# Altium schematic sheet iMX6SL_SoC.SchDoc
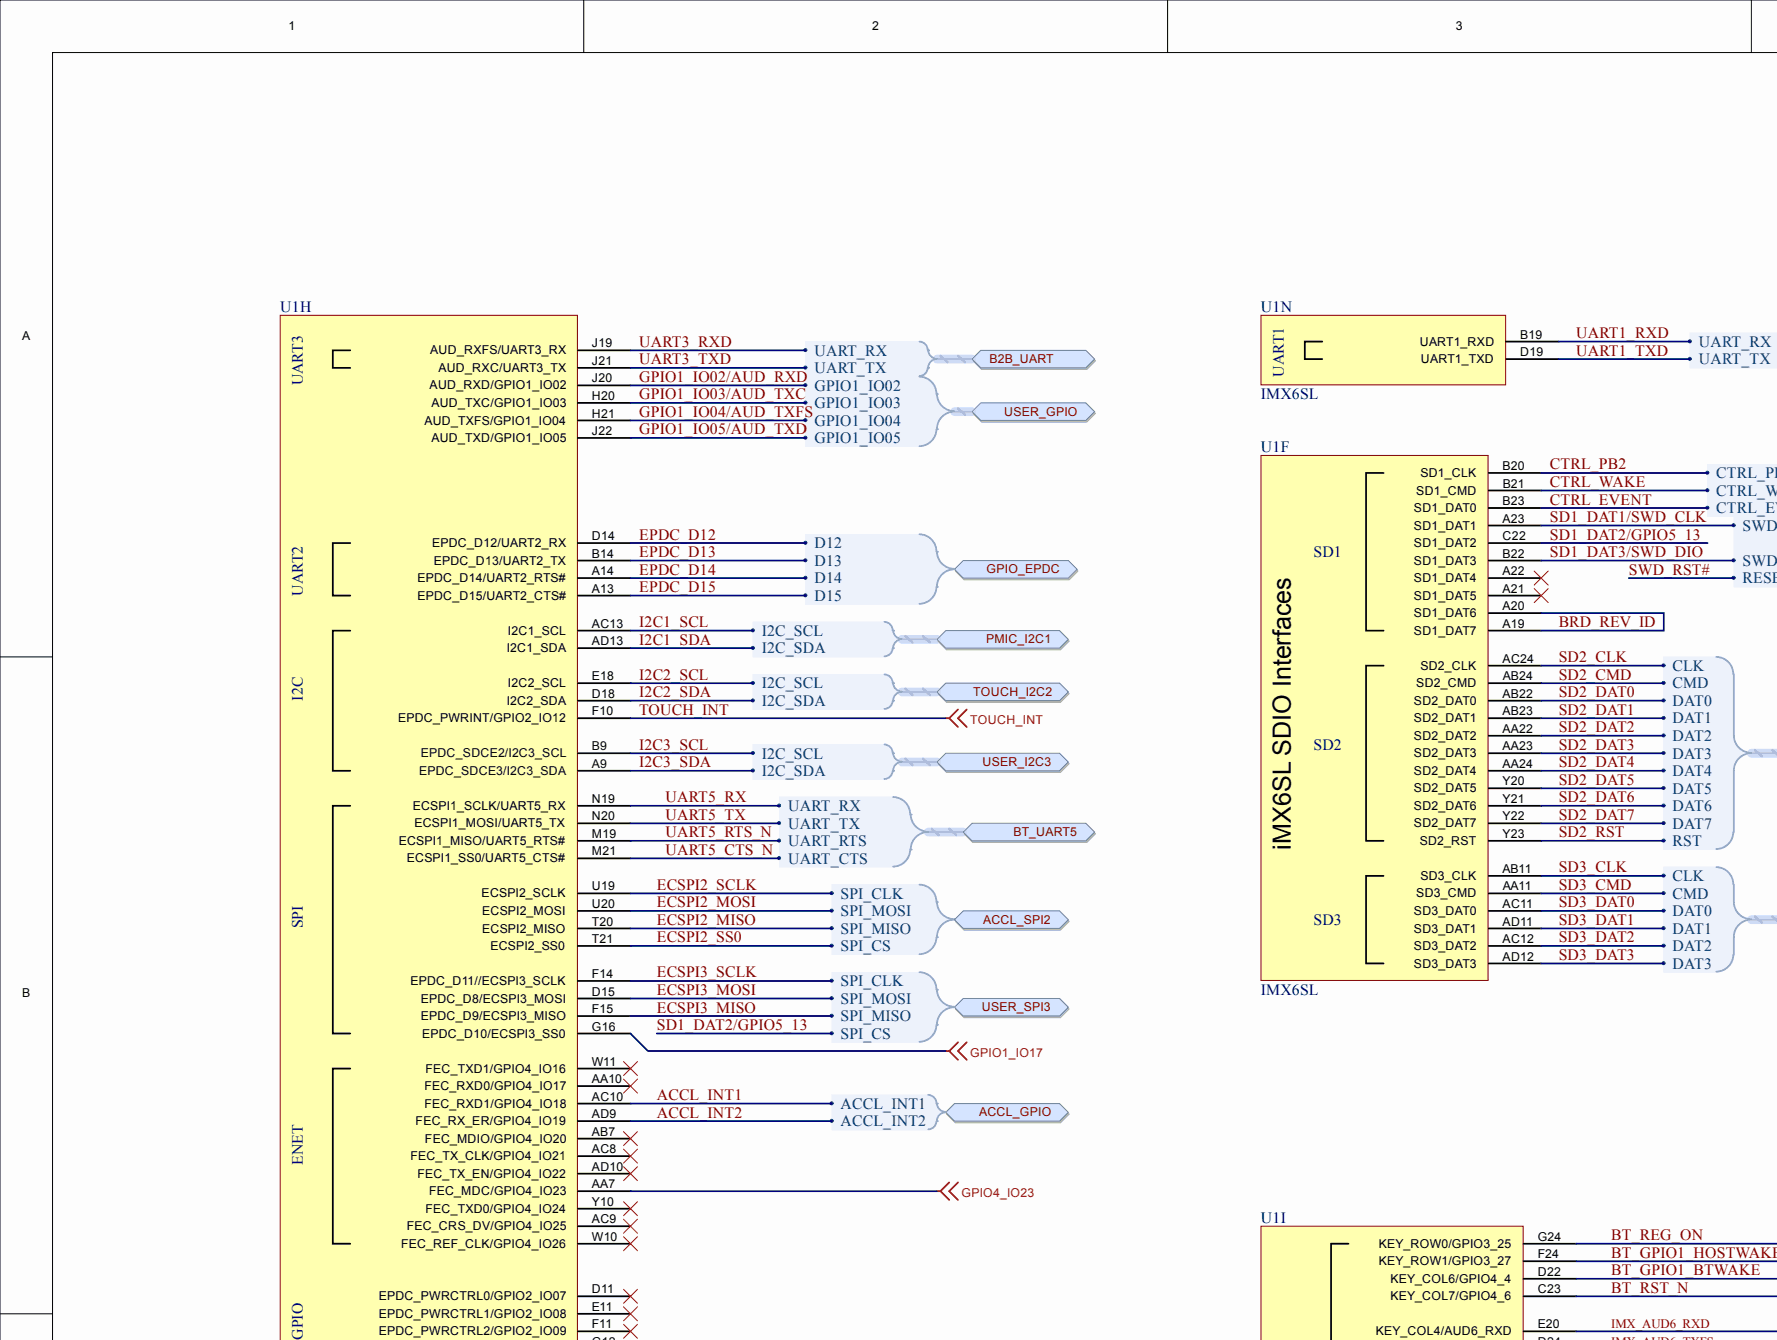
[diagram p0: iMX6SL_SoC.SchDoc, top left]
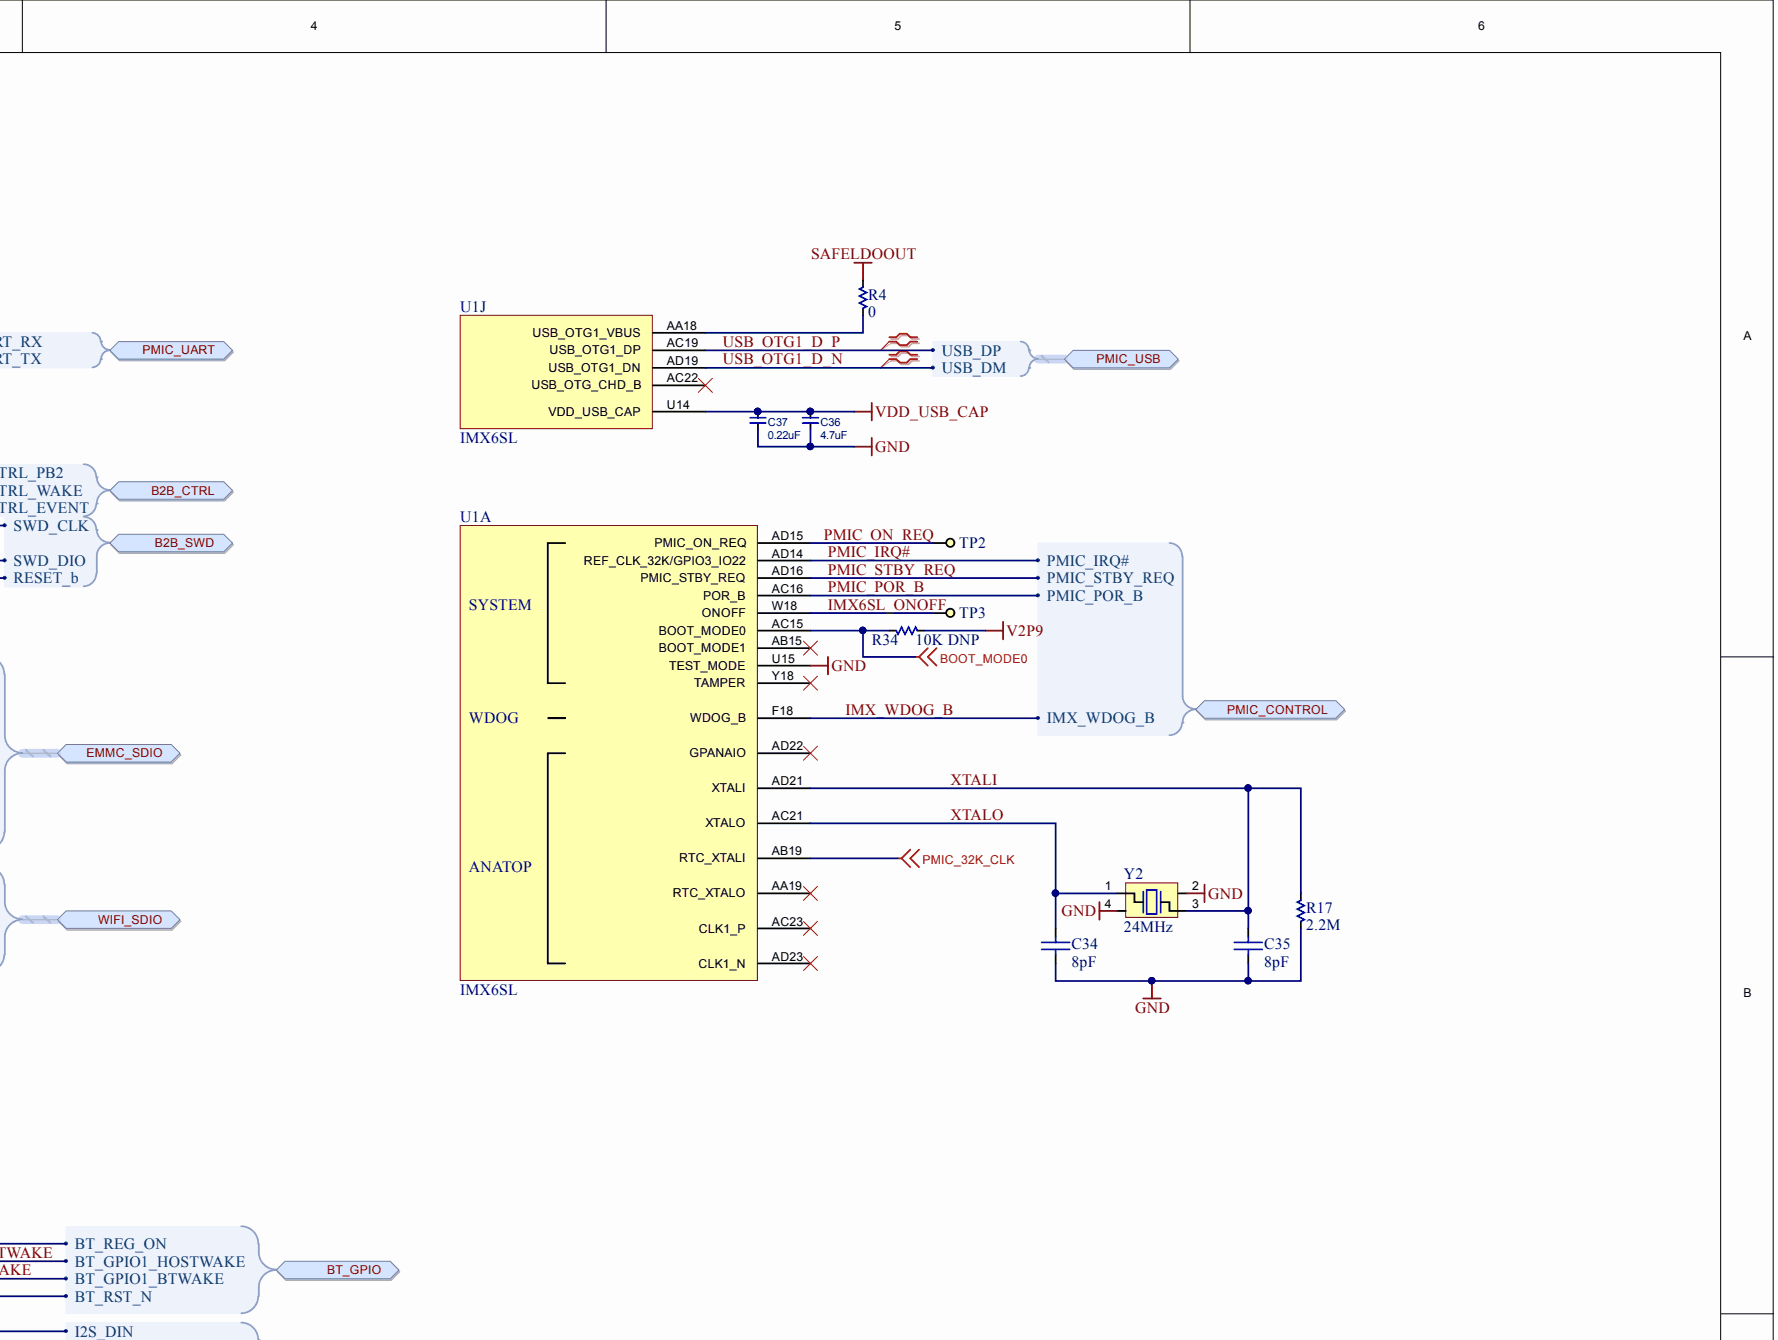
[diagram p1: iMX6SL_SoC.SchDoc, top right]
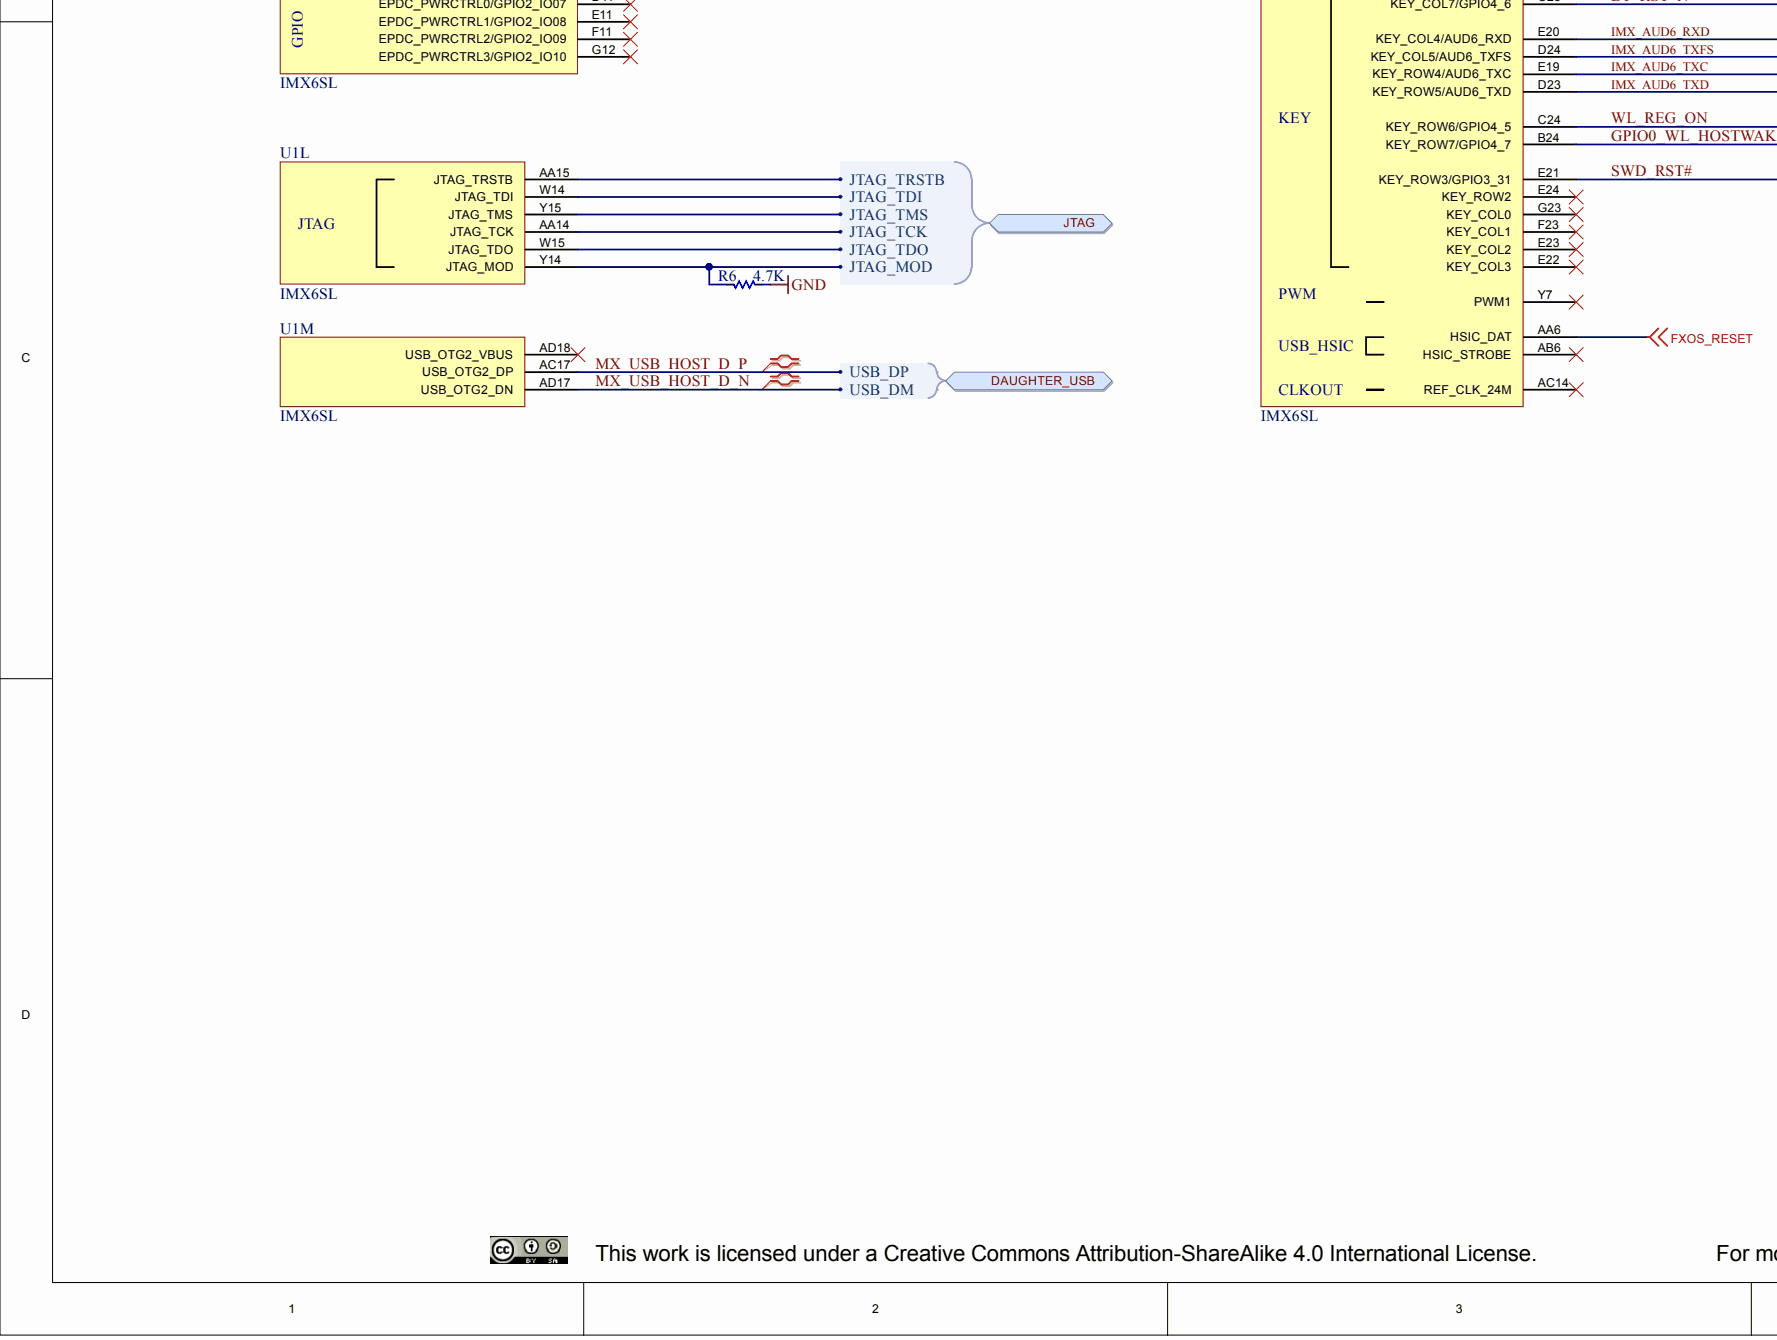
[diagram p2: iMX6SL_SoC.SchDoc, bottom left]
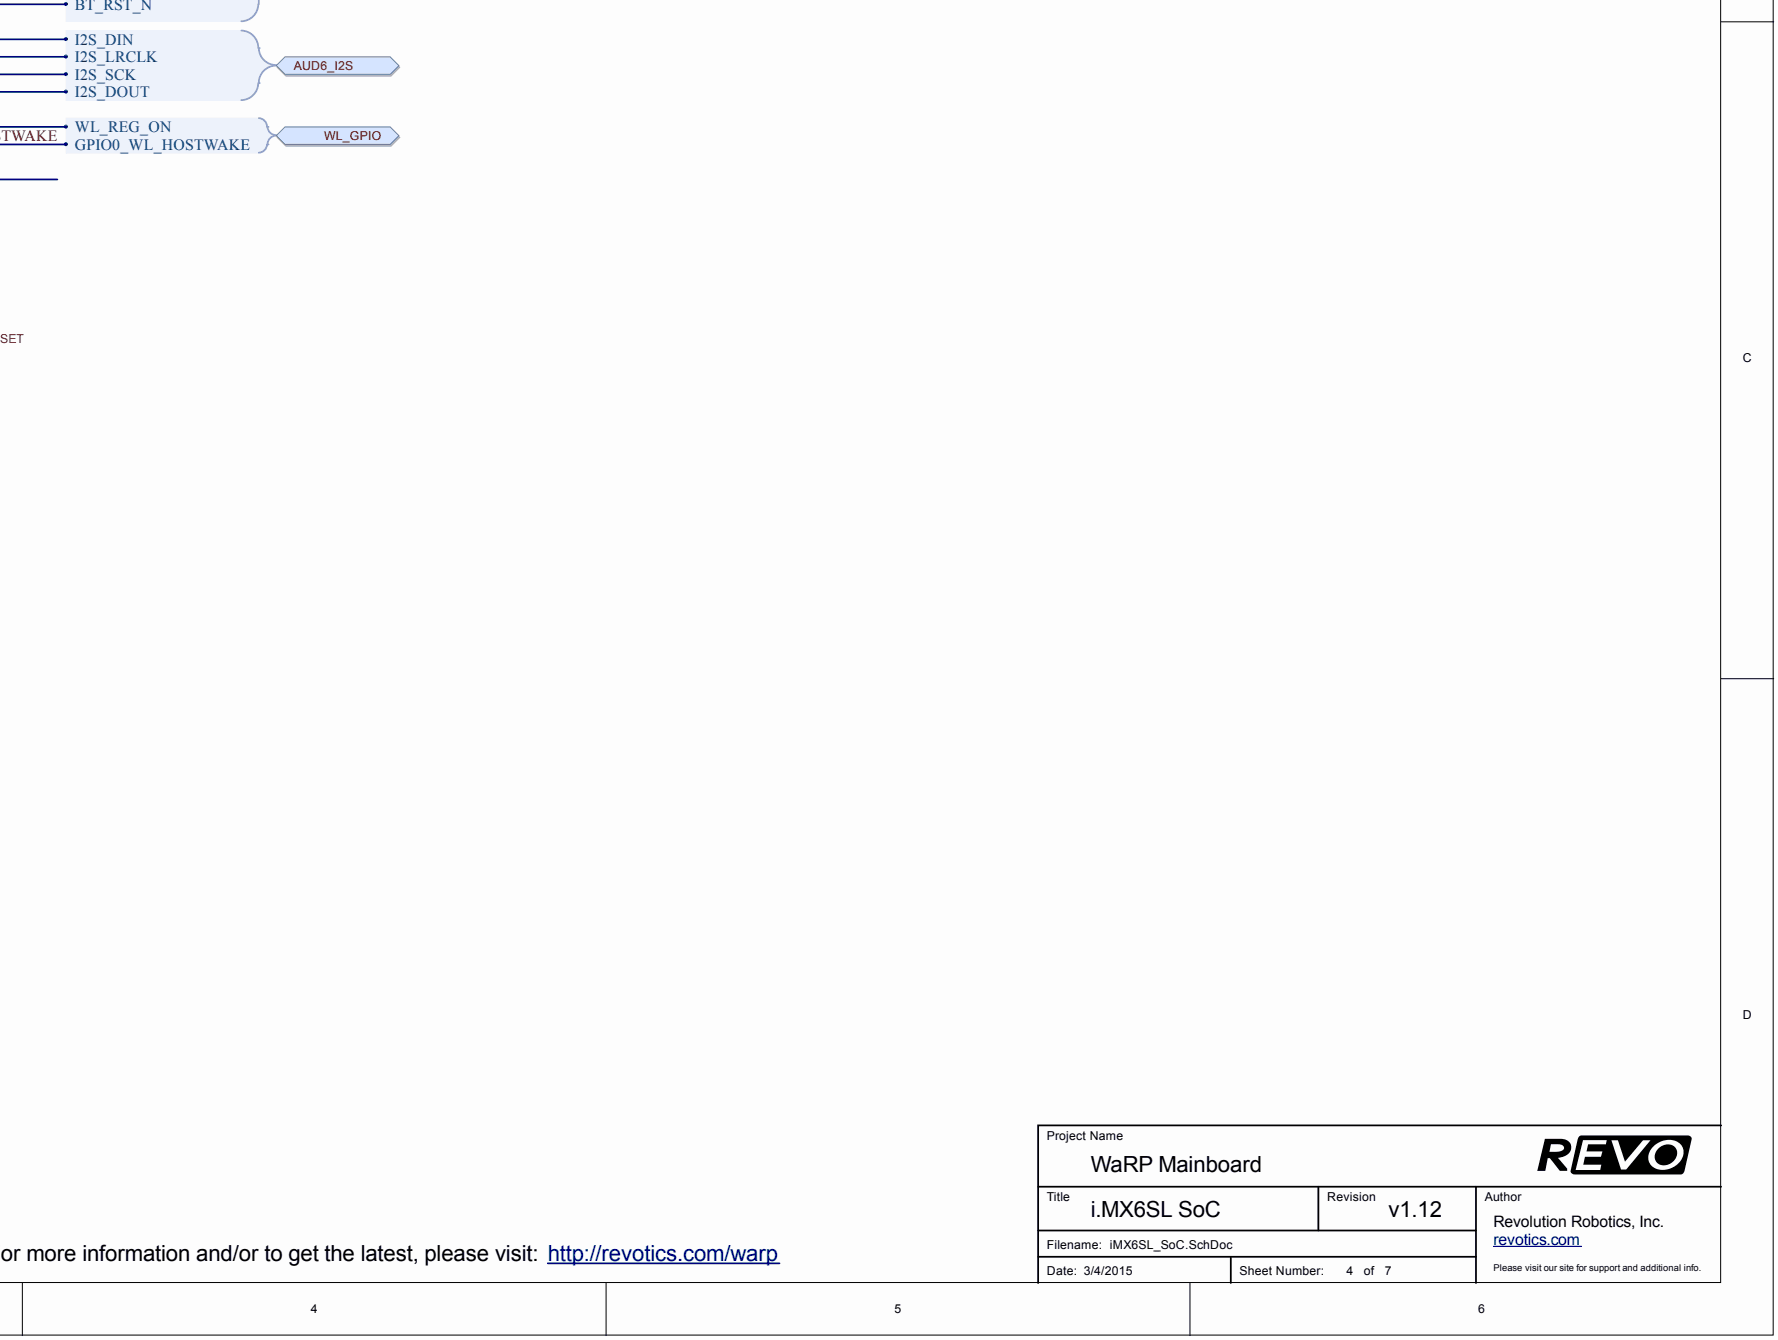
[diagram p3: iMX6SL_SoC.SchDoc, bottom right]

## Parts
C34  value 8pF  [0201 (0603) Cap High Density]  (hidden comment: Capacitor)
C35  value 8pF  [0201 (0603) Cap High Density]  (hidden comment: Capacitor)
C36  value 4.7uF  [0402 (1005) Cap High Density]  (hidden comment: CapacitorSM)
C37  value 0.22uF  [0201 (0603) Cap High Density]  (hidden comment: CapacitorSM)
R4  value 0  [0201 (0603) Res High Density]  (hidden comment: Resistor)
R6  value 4.7K  [0201 (0603) Res High Density]  (hidden comment: Resistor)
R17  Resistor  [0201 (0603) Res High Density]  (value not drawn)
R34  value 10K DNP  [0201 (0603) Res High Density]  (hidden comment: Resistor)
TP2  TP  [8mil]  (no BOM)
TP3  TP  [8mil]  (no BOM)
U1  IMX6SL  [BGA432C50P24X24_1300X1300X110]  (parts A,F,H,I,J,L,M,N)
Y2  value 24MHz  [TXC 1.6mm x 1.2mm]  (hidden comment: XTAL 4Pad SMD)

## Ports
ACCL_GPIO, ACCL_GPIO.ACCL_INT1, ACCL_GPIO.ACCL_INT2, ACCL_SPI2, ACCL_SPI2.SPI_CLK, ACCL_SPI2.SPI_CS, ACCL_SPI2.SPI_MISO, ACCL_SPI2.SPI_MOSI, AUD6_I2S, AUD6_I2S.I2S_DIN, AUD6_I2S.I2S_DOUT, AUD6_I2S.I2S_LRCLK, AUD6_I2S.I2S_SCK, B2B_CTRL, B2B_CTRL.CTRL_EVENT, B2B_CTRL.CTRL_PB2, B2B_CTRL.CTRL_WAKE, B2B_SWD, B2B_SWD.RESET_B, B2B_SWD.SWD_CLK, B2B_SWD.SWD_DIO, B2B_UART, B2B_UART.UART_RX, B2B_UART.UART_TX, BT_GPIO, BT_GPIO.BT_GPIO1_BTWAKE, BT_GPIO.BT_GPIO1_HOSTWAKE, BT_GPIO.BT_REG_ON, BT_GPIO.BT_RST_N, BT_UART5, BT_UART5.UART_CTS, BT_UART5.UART_RTS, BT_UART5.UART_RX, BT_UART5.UART_TX, DAUGHTER_USB, DAUGHTER_USB.USB_DM, DAUGHTER_USB.USB_DP, EMMC_SDIO, EMMC_SDIO.CLK, EMMC_SDIO.CMD, EMMC_SDIO.DAT0, EMMC_SDIO.DAT1, EMMC_SDIO.DAT2, EMMC_SDIO.DAT3, EMMC_SDIO.DAT4, EMMC_SDIO.DAT5, EMMC_SDIO.DAT6, EMMC_SDIO.DAT7, EMMC_SDIO.RST, GPIO_EPDC, GPIO_EPDC.D12, GPIO_EPDC.D13, GPIO_EPDC.D14, GPIO_EPDC.D15, JTAG, JTAG.JTAG_MOD, JTAG.JTAG_TCK, JTAG.JTAG_TDI, JTAG.JTAG_TDO, JTAG.JTAG_TMS, JTAG.JTAG_TRSTB, PMIC_CONTROL, PMIC_CONTROL.IMX_WDOG_B, PMIC_CONTROL.PMIC_IRQ#, PMIC_CONTROL.PMIC_POR_B, PMIC_CONTROL.PMIC_STBY_REQ, PMIC_I2C1, PMIC_I2C1.I2C_SCL, PMIC_I2C1.I2C_SDA, PMIC_UART, PMIC_UART.UART_RX, PMIC_UART.UART_TX, PMIC_USB, PMIC_USB.USB_DM, PMIC_USB.USB_DP, TOUCH_I2C2, TOUCH_I2C2.I2C_SCL, TOUCH_I2C2.I2C_SDA, USER_GPIO, USER_GPIO.GPIO1_IO02, USER_GPIO.GPIO1_IO03, USER_GPIO.GPIO1_IO04, USER_GPIO.GPIO1_IO05, USER_I2C3, USER_I2C3.I2C_SCL, USER_I2C3.I2C_SDA, USER_SPI3, USER_SPI3.SPI_CLK, USER_SPI3.SPI_CS, USER_SPI3.SPI_MISO, USER_SPI3.SPI_MOSI, WIFI_SDIO, WIFI_SDIO.CLK, WIFI_SDIO.CMD, WIFI_SDIO.DAT0, WIFI_SDIO.DAT1, WIFI_SDIO.DAT2, WIFI_SDIO.DAT3, WL_GPIO, WL_GPIO.GPIO0_WL_HOSTWAKE, WL_GPIO.WL_REG_ON

## Nets
BOOT_MODE0: R34.2 U1.AC15
FXOS_RESET: U1.AA6
GND: C34.2 C35.2 C36.2 C37.2 R6.1 R17.2 U1.U15 Y2.2 Y2.4
GPIO1_IO17: U1.G16
GPIO4_IO23: U1.AA7
PMIC_32K_CLK: U1.AB19
SAFELDOOUT: R4.1
V2P9: R34.1
VDD_USB_CAP: C36.1 C37.1 U1.U14
ACCL_INT1: U1.AC10 ; port ACCL_GPIO
ACCL_INT2: U1.AD9 ; port ACCL_GPIO
BRD_REV1.11: U1.A19 U1.A20
BT_GPIO1_BTWAKE: U1.D22 ; port BT_GPIO
BT_GPIO1_HOSTWAKE: U1.F24 ; port BT_GPIO
BT_REG_ON: U1.G24 ; port BT_GPIO
BT_RST_N: U1.C23 ; port BT_GPIO
CTRL_EVENT: U1.B23 ; port B2B_CTRL
CTRL_PB2: U1.B20 ; port B2B_CTRL
CTRL_WAKE: U1.B21 ; port B2B_CTRL
ECSPI2_MISO: U1.T20 ; port ACCL_SPI2
ECSPI2_MOSI: U1.U20 ; port ACCL_SPI2
ECSPI2_SCLK: U1.U19 ; port ACCL_SPI2
ECSPI2_SS0: U1.T21 ; port ACCL_SPI2
ECSPI3_MISO: U1.F15 ; port USER_SPI3
ECSPI3_MOSI: U1.D15 ; port USER_SPI3
ECSPI3_SCLK: U1.F14 ; port USER_SPI3
EPDC_D12: U1.D14 ; port GPIO_EPDC
EPDC_D13: U1.B14 ; port GPIO_EPDC
EPDC_D14: U1.A14 ; port GPIO_EPDC
EPDC_D15: U1.A13 ; port GPIO_EPDC
GPIO0_WL_HOSTWAKE: U1.B24 ; port WL_GPIO
GPIO1_IO02/AUD_RXD: U1.J20 ; port USER_GPIO
GPIO1_IO03/AUD_TXC: U1.H20 ; port USER_GPIO
GPIO1_IO04/AUD_TXFS: U1.H21 ; port USER_GPIO
GPIO1_IO05/AUD_TXD: U1.J22 ; port USER_GPIO
I2C1_SCL: U1.AC13 ; port PMIC_I2C1
I2C1_SDA: U1.AD13 ; port PMIC_I2C1
I2C2_SCL: U1.E18 ; port TOUCH_I2C2
I2C2_SDA: U1.D18 ; port TOUCH_I2C2
I2C3_SCL: U1.B9 ; port USER_I2C3
I2C3_SDA: U1.A9 ; port USER_I2C3
IMX6SL_ONOFF: TP3.1 U1.W18
IMX_AUD6_RXD: U1.E20 ; port AUD6_I2S
IMX_AUD6_TXC: U1.E19 ; port AUD6_I2S
IMX_AUD6_TXD: U1.D23 ; port AUD6_I2S
IMX_AUD6_TXFS: U1.D24 ; port AUD6_I2S
IMX_WDOG_B: U1.F18 ; port PMIC_CONTROL
MX_USB_HOST_D_N: U1.AD17 ; port DAUGHTER_USB
MX_USB_HOST_D_P: U1.AC17 ; port DAUGHTER_USB
PMIC_IRQ#: U1.AD14 ; port PMIC_CONTROL
PMIC_ON_REQ: TP2.1 U1.AD15
PMIC_POR_B: U1.AC16 ; port PMIC_CONTROL
PMIC_STBY_REQ: U1.AD16 ; port PMIC_CONTROL
SD1_DAT1/SWD_CLK: U1.A23 ; port B2B_SWD
SD1_DAT2/GPIO5_13: U1.C22 ; port USER_SPI3
SD1_DAT3/SWD_DIO: U1.B22 ; port B2B_SWD
SD2_CLK: U1.AC24 ; port EMMC_SDIO
SD2_CMD: U1.AB24 ; port EMMC_SDIO
SD2_DAT0: U1.AB22 ; port EMMC_SDIO
SD2_DAT1: U1.AB23 ; port EMMC_SDIO
SD2_DAT2: U1.AA22 ; port EMMC_SDIO
SD2_DAT3: U1.AA23 ; port EMMC_SDIO
SD2_DAT4: U1.AA24 ; port EMMC_SDIO
SD2_DAT5: U1.Y20 ; port EMMC_SDIO
SD2_DAT6: U1.Y21 ; port EMMC_SDIO
SD2_DAT7: U1.Y22 ; port EMMC_SDIO
SD2_RST: U1.Y23 ; port EMMC_SDIO
SD3_CLK: U1.AB11 ; port WIFI_SDIO
SD3_CMD: U1.AA11 ; port WIFI_SDIO
SD3_DAT0: U1.AC11 ; port WIFI_SDIO
SD3_DAT1: U1.AD11 ; port WIFI_SDIO
SD3_DAT2: U1.AC12 ; port WIFI_SDIO
SD3_DAT3: U1.AD12 ; port WIFI_SDIO
SWD_RST#: U1.E21 ; port B2B_SWD
TOUCH_INT: U1.F10
UART1_RXD: U1.B19 ; port PMIC_UART
UART1_TXD: U1.D19 ; port PMIC_UART
UART3_RXD: U1.J19 ; port B2B_UART
UART3_TXD: U1.J21 ; port B2B_UART
UART5_CTS_N: U1.M21 ; port BT_UART5
UART5_RTS_N: U1.M19 ; port BT_UART5
UART5_RX: U1.N19 ; port BT_UART5
UART5_TX: U1.N20 ; port BT_UART5
USB_OTG1_D_N: U1.AD19 ; port PMIC_USB
USB_OTG1_D_P: U1.AC19 ; port PMIC_USB
WL_REG_ON: U1.C24 ; port WL_GPIO
XTALI: C35.1 R17.1 U1.AD21 Y2.3
XTALO: C34.1 U1.AC21 Y2.1
NetR4_2: R4.2 U1.AA18
NetR6_2: R6.2 U1.Y14 ; port JTAG
NetU1_AA14: U1.AA14 ; port JTAG
NetU1_AA15: U1.AA15 ; port JTAG
NetU1_D11: U1.D11
NetU1_E11: U1.E11
NetU1_F11: U1.F11
NetU1_G12: U1.G12
NetU1_W14: U1.W14 ; port JTAG
NetU1_W15: U1.W15 ; port JTAG
NetU1_Y15: U1.Y15 ; port JTAG

Not connected: U1 A21 A22 AA10 AA19 AB6 AB7 AB15 AC8 AC9 AC14 AC22 AC23 AD10 AD18 AD22 AD23 E22 E23 E24 F23 G23 W10 W11 Y7 Y10 Y18

## Library data of the parts
C34 C35 = Capacitor: Manufacturer: TDK; Part No.: C0603C0G1E080D030BA; Vendor: Digikey; Component Kind: Standard; Description: Capacitor; Library Name: Passive.SchLib; STOCK: Yes
C36 = CapacitorSM: Capacitor; Manufacturer: TDK; Part No.: C1005X5R0J475M050BC; Vendor: Digikey; Voltage: 6.3; Component Kind: Standard; Library Name: Passive.SchLib
C37 = CapacitorSM: Capacitor; Manufacturer: TDK; Part No.: C0603X5R0J224K030BB; Vendor: Digikey; Voltage: 6.3; Component Kind: Standard; Library Name: Passive.SchLib
R4 = Resistor: Manufacturer: Vishay / Dale; Part No.: CRCW02010000Z0ED; Part No. Ref: CRCW[PACKAGE][VALUE]; Vendor: Digikey; Component Kind: Standard; Description: Resistor; Library Name: Passive.SchLib; Simulation: RES
R6 = Resistor: Manufacturer: Vishay / Dale; Part No.: CRCW02014K70FKED; Part No. Ref: CRCW[PACKAGE][VALUE]; Vendor: Digikey; Component Kind: Standard; Description: Resistor; Library Name: Passive.SchLib; Simulation: RES
R17 = Resistor: Manufacturer: Panasonic Electronic Components; Part No.: ERJ-1GEJ225C; Part No. Ref: CRCW[PACKAGE][VALUE]; Value: 2.2M; Vendor: Digkiey; Component Kind: Standard; Description: Resistor; Library Name: Passive.SchLib; Simulation: RES
R34 = Resistor: Manufacturer: Vishay / Dale; Part No.: CRCW020110K0FNED; Part No. Ref: CRCW[PACKAGE][VALUE]; Vendor: Digikey; Component Kind: Standard; Description: Resistor; Library Name: Passive.SchLib; Simulation: RES
TP2 TP3 = TP: Test Pad; Library Name: TestPads.SchLib
U1 = IMX6SL: Freescale iMX6 SoloLite; Part No.: MCIMX6L8DVN10AB; Vendor: Verical; Component Kind: Standard; ComponentLink1Description: Datasheet; ComponentLink2Description: Freescale Webpage; ComponentLink2URL: http://www.freescale.com/webapp/sps/site/prod_summary.jsp?code=i.MX6SL; Library Name: iMX6.SchLib; Manufacturer: Freescale; Supplier 1: Newark; Supplier Part Number 1: 31W8709; ComponentLink1URL: R:\Hardware\Part Datasheets\Freescale\IMX6SLCEC.pdf
Y2 = XTAL 4Pad SMD: Manufacturer: Abracon; Part No.: ABM12-103-24.000MHZ-T; Vendor: ATMI; Component Kind: Standard; Library Name: XTAL.SchLib
